# Altium schematic sheet USB2UART.SchDoc
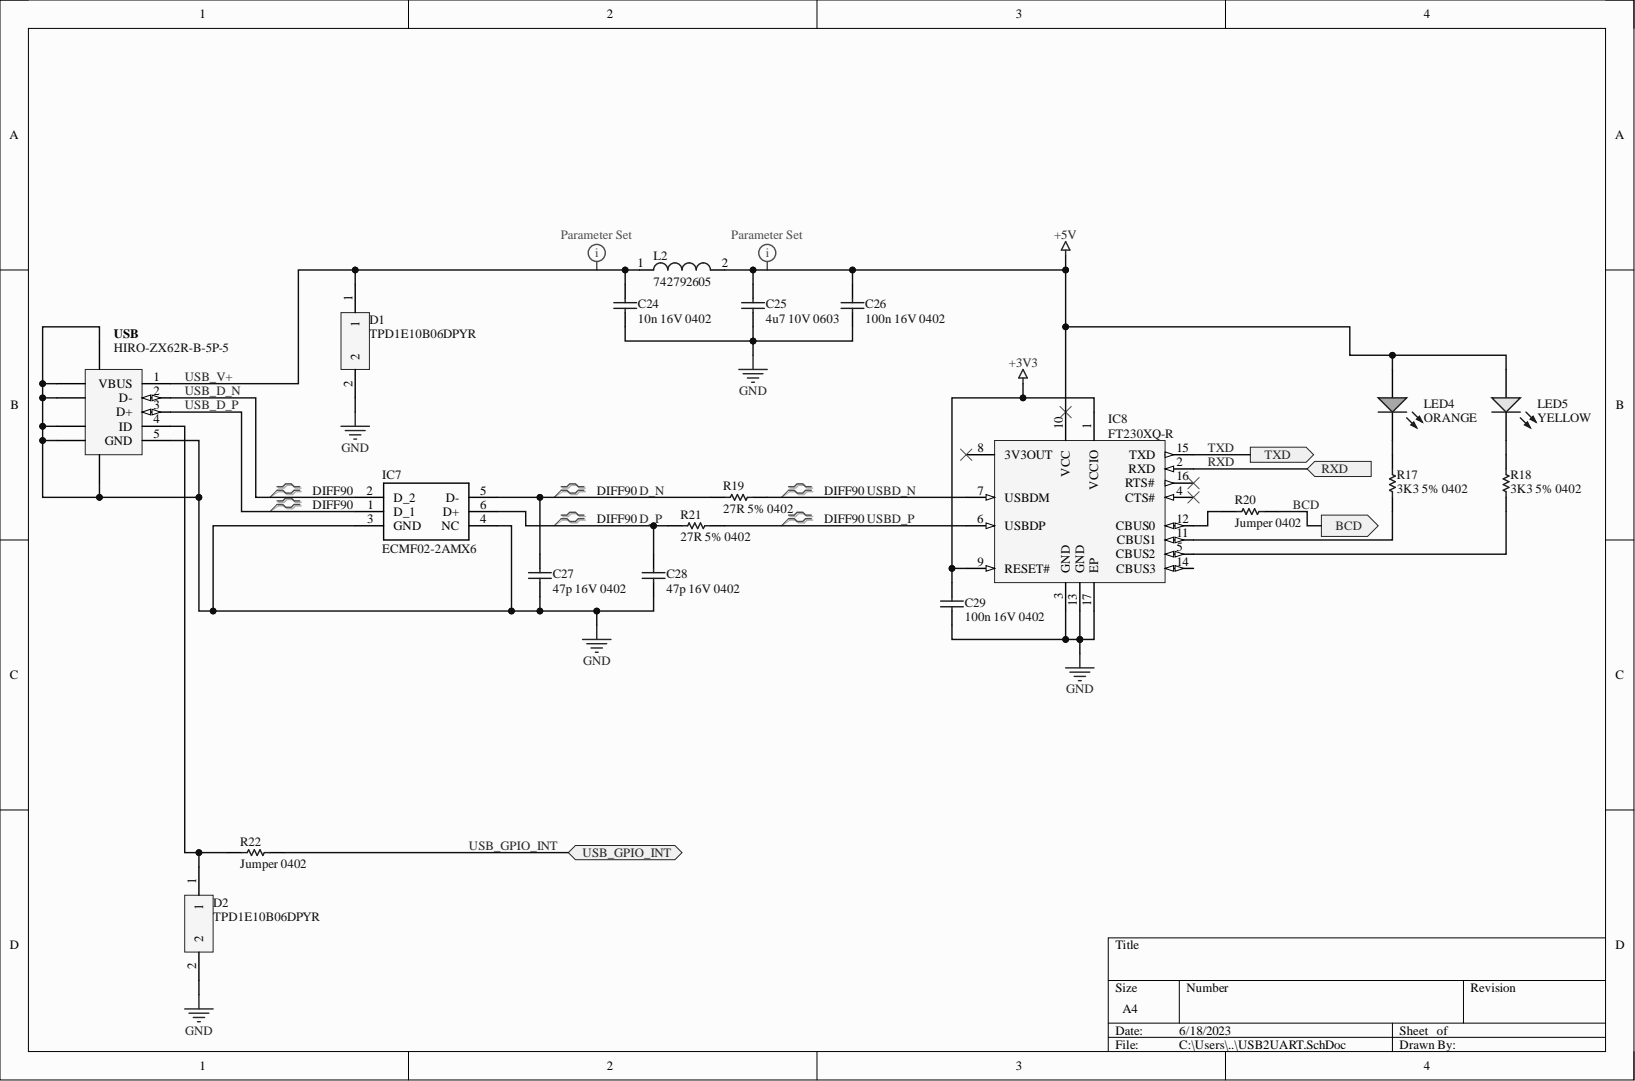
[diagram p0: USB2UART.SchDoc]

## Parts
C24  10n 16V 0402  [CAPC0402(1005)33_L]
C25  4u7 10V 0603  [CAPC0603(1608)100_L]
C26  100n 16V 0402  [CAPC0402(1005)60_L]
C27  47p 16V 0402  [CAPC0402(1005)60_L]
C28  47p 16V 0402  Case-EIA: 0402  [CAPC0402(1005)60_L]
C29  100n 16V 0402  [CAPC0402(1005)60_N]
D1  TPD1E10B06DPYR  [DPY0002A_M]
D2  TPD1E10B06DPYR  [DPY0002A_M]
IC7  ECMF02-2AMX6  [SON50P150X170X55-6N-D]
IC8  FT230XQ-R  [QFN-16_L]
L2  742792605  [0603(1608)_L]
LED4  ORANGE  [LED_0402]
LED5  YELLOW  [LED_0402]
R17  3K3 5% 0402  [RESC0402(1005)_L]
R18  3K3 5% 0402  [RESC0402(1005)_L]
R19  27R 5% 0402  Case-EIA: 0402  [RESC0402(1005)_L]
R20  Jumper 0402  Case-EIA: 0402  [RESC0402(1005)_L]
R21  27R 5% 0402  Case-EIA: 0402  [RESC0402(1005)_L]
R22  Jumper 0402  Case-EIA: 0402  [RESC0402(1005)_L]
USB  HIRO-ZX62R-B-5P-5  [HIRO-ZX62R-B-5P_V]

## Ports
RXD, TXD, USB_GPIO_INT, ~{BCD}

## Nets
+3V3: C29.2 IC8.1(VCCIO) IC8.9(RESET#)
+5V: C25.2 C26.2 IC8.10(VCC) L2.2 LED4.ANODE LED5.ANODE
GND: C24.1 C25.1 C26.1 C27.1 C28.1 C29.1 D1.2 D2.2 IC7.3(GND) IC7.4(NC) IC8.3(GND) IC8.13(GND) IC8.17(EP) USB.5(GND) USB.6 USB.7 USB.8 USB.9 USB.10 USB.11
BCD: R20.2 ; port ~{BCD}
D_N: C27.2 IC7.5(D-) R19.1
D_P: C28.2 IC7.6(D+) R21.1
RXD: IC8.2(RXD) ; port RXD
TXD: IC8.15(TXD) ; port TXD
USB_D_N: IC7.2(D_2) USB.2(D-)
USB_D_P: IC7.1(D_1) USB.3(D+)
USB_GPIO_INT: R22.2 ; port USB_GPIO_INT
USB_V+: C24.2 D1.1 L2.1 USB.1(VBUS)
USBD_N: IC8.7(USBDM) R19.2
USBD_P: IC8.6(USBDP) R21.2
NetD2_1: D2.1 R22.1 USB.4(ID)
NetIC8_5: IC8.5(CBUS2) R18.1
NetIC8_11: IC8.11(CBUS1) R17.1
NetIC8_12: IC8.12(CBUS0) R20.1
NetLED4_CATHODE: LED4.CATHODE R17.2
NetLED5_CATHODE: LED5.CATHODE R18.2

Not connected: IC8 4(CTS#) 8(3V3OUT) 14(CBUS3) 16(RTS#)

## Library data of the parts
C24 = CMP-1034-01875-1: CAP 10nF 16V ±10% 0402 (1005 Metric) Thickness 0.33mm SMD; Case-EIA: 0402; Case-Metric: 1005; Tolerance: Â±10%; Component Kind: Standard; Library Name: Altium Content Vault; Max Thickness: 0.33 mm; Rated Voltage: 16 V; Technology: SMT; Value: 10nF
C25 = CMP-1035-02787-1: CAP 4.7uF 10V ±20% 0603 (1608 Metric) Thickness 1mm SMD; Case-EIA: 0603; Case-Metric: 1608; Tolerance: Â±20%; Component Kind: Standard; Library Name: Altium Content Vault; Max Thickness: 1 mm; Rated Voltage: 10 V; Technology: SMT; Value: 4.7uF
C26 C29 = CMP-1034-02069-1: CAP 100nF 16V ±10% 0402 (1005 Metric) Thickness 0.6mm SMD; Case-EIA: 0402; Case-Metric: 1005; Tolerance: Â±10%; Component Kind: Standard; Library Name: Altium Content Vault; Max Thickness: 0.6 mm; Rated Voltage: 16 V; Technology: SMT; Value: 100nF
C27 = CMP-1034-01081-1: CAP 47pF 16V ±10% 0402 (1005 Metric) Thickness 0.6mm SMD; Case-EIA: 0402; Case-Metric: 1005; Tolerance: Â±10%; Component Kind: Standard; Library Name: Altium Content Vault; Max Thickness: 0.6 mm; Rated Voltage: 16 V; Technology: SMT; Value: 47pF
C28 = CMP-1034-01081-1: CAP 47pF 16V ±10% 0402 (1005 Metric) Thickness 0.6mm SMD; Case-Metric: 1005; Tolerance: Â±10%; Component Kind: Standard; Library Name: Altium Content Vault; Max Thickness: 0.6 mm; Rated Voltage: 16 V; Technology: SMT; Value: 47pF
D1 D2 = CMP-0326-00004-2: ESD in 0402 Package with 10 pF Capacitance and 6 V Breakdown, 1 Channel, -40 to +125 degC, 2-pin X2SON (DPY), Green (RoHS & no Sb/Br); Manufacturer: Texas Instruments; Breakdown Voltage(Min)(V): 6; Eco-Plan: TBD; IEC 61000-4-2 Air-Gap(+/- kV): 30; IO Capacitance(Typ)(pF): 10; SubFamily: ESD Solution; Component Kind: Standard; ComponentLink1Description: Manufacturer URL; ComponentLink1URL: http://www.ti.com/; ComponentLink2Description: Datasheet; ComponentLink2URL: http://www.ti.com/general/docs/lit/getliterature.tsp?genericPartNumber=TPD1E10B06&fileType=pdf; ComponentLink3Description: URL Link1; ComponentLink3URL: SamplesURL:http://www.ti.com/product/tpd1e10b06#samples; DatasheetVersion: SLLSEB1; IEC 61000-4-2 Contact(+/- kV): 30; IO Leakage Current(nA): 10; Library Name: Altium Content Vault; Mounting Technology: Surface Mount; PackageDescription: 2-Pin Plastic Small Outline No-Lead 1.0 x 0.6 x 0.4 mm Body; PackageReference: DPY0002A; PackageVersion: revA, Apr-2010; PartNumber: TPD1E10B06DPYR
IC7 = ECMF02-2AMX6: Integrated Circuit; Manufacturer_Name: STMicroelectronics; Component Kind: Standard; Datasheet Link: http://www.st.com/content/ccc/resource/technical/document/datasheet/3a/28/cf/d0/31/63/48/8c/CD00282307.pdf/files/CD00282307.pdf/jcr:content/translations/en.CD00282307.pdf; Height: 0.55mm; Manufacturer_Part_Number: ECMF02-2AMX6; Mouser Part Number: 511-ECMF02-2AMX6; Mouser Price/Stock: https://www.mouser.com/Search/Refine.aspx?Keyword=511-ECMF02-2AMX6; RS Part Number: 8805276; RS Price/Stock: https://uk.rs-online.com/web/p/products/8805276; Library Name: bleimuv6_intlib.IntLib
IC8 = CMP-0248-00010-2: USB to Basic UART Interface Chip, UHCI/OHCI/EHCI Compatible, USB 2.0 Compatible, -40 to +85 degC, 16-Pin QFN, Pb-Free, Tape and Reel; Manufacturer: FTDI; Code_JEDEC: MO-220WGGC; Component Kind: Standard; ComponentLink1Description: Manufacturer URL; ComponentLink1URL: http://www.ftdichip.com/; ComponentLink2Description: Datasheet; ComponentLink2URL: http://www.ftdichip.com/Support/Documents/DataSheets/ICs/DS_FT230X.pdf; ComponentLink3Description: FT230X Product Page; ComponentLink3URL: www.ftdichip.com/Products/ICs/FT230X.html; DatasheetVersion: ver 1.2, Feb-2013; Library Name: Altium Content Vault; PackageDescription: 16-Pin Quad Flat No-Lead, 4x4 mm Body, 0.65 mm Pitch; PackageReference: QFN-16; PackageVersion: Feb-13; PartNumber: FT230XQ-R; RevisionNote: DatasheetVersion updated to 1.2 Feb-2013
L2 = CMP-0220-00044-1: SMD EMI Suppression Ferrite Bead WE-CBF, Z = 33 Ohm; Impedance: 33 Ohm; Component Kind: Standard; ComponentLink1Description: Manufacturer Link; ComponentLink1URL: http://www.we-online.com; ComponentLink2Description: Datasheet; ComponentLink2URL: http://www.we-online.de/katalog/media/pdf/742792012.pdf; DatasheetVersion: Dec-2005; PackageDescription: Chip Inductor, 2-Leads, Body 2.0 x 1.2 mm; PackageReference: SMD-0805; PackageVersion: 2005; Library Name: bleimuv6_intlib.IntLib
LED4 LED5 = LED: Generic 0402 LED; Component Kind: Standard; Library Name: bleimuv6_schlib.SchLib
R17 R18 = CMP-1011-00086-1: 3K3 0.063W 5% 0402 (1005 Metric)  SMD; Case-EIA: 0402; Case-Metric: 1005; Value: 3300.00 Ohm; Component Kind: Standard; Library Name: Altium Content Vault; Power: 0.063 W; Technology: SMT; Tolerance: 5 %
R19 R21 = CMP-1011-00036-1: 27R 0.063W 5% 0402 (1005 Metric)  SMD; Case-Metric: 1005; Value: 27.00 Ohm; Component Kind: Standard; Library Name: Altium Content Vault; Power: 0.063 W; Technology: SMT; Tolerance: 5 %
R20 R22 = CMP-1011-00001-1: Jumper 0402 (1005 Metric); Case-Metric: 1005; Power: None; Value: 0.00 Ohm; Component Kind: Standard; Library Name: Altium Content Vault; Technology: SMT; Tolerance: None
USB = HIRO-ZX62R-B-5P-5: Component Kind: Standard; Library Name: bleimuv6_intlib.IntLib
